# Altium schematic sheet USB.SchDoc(USB)
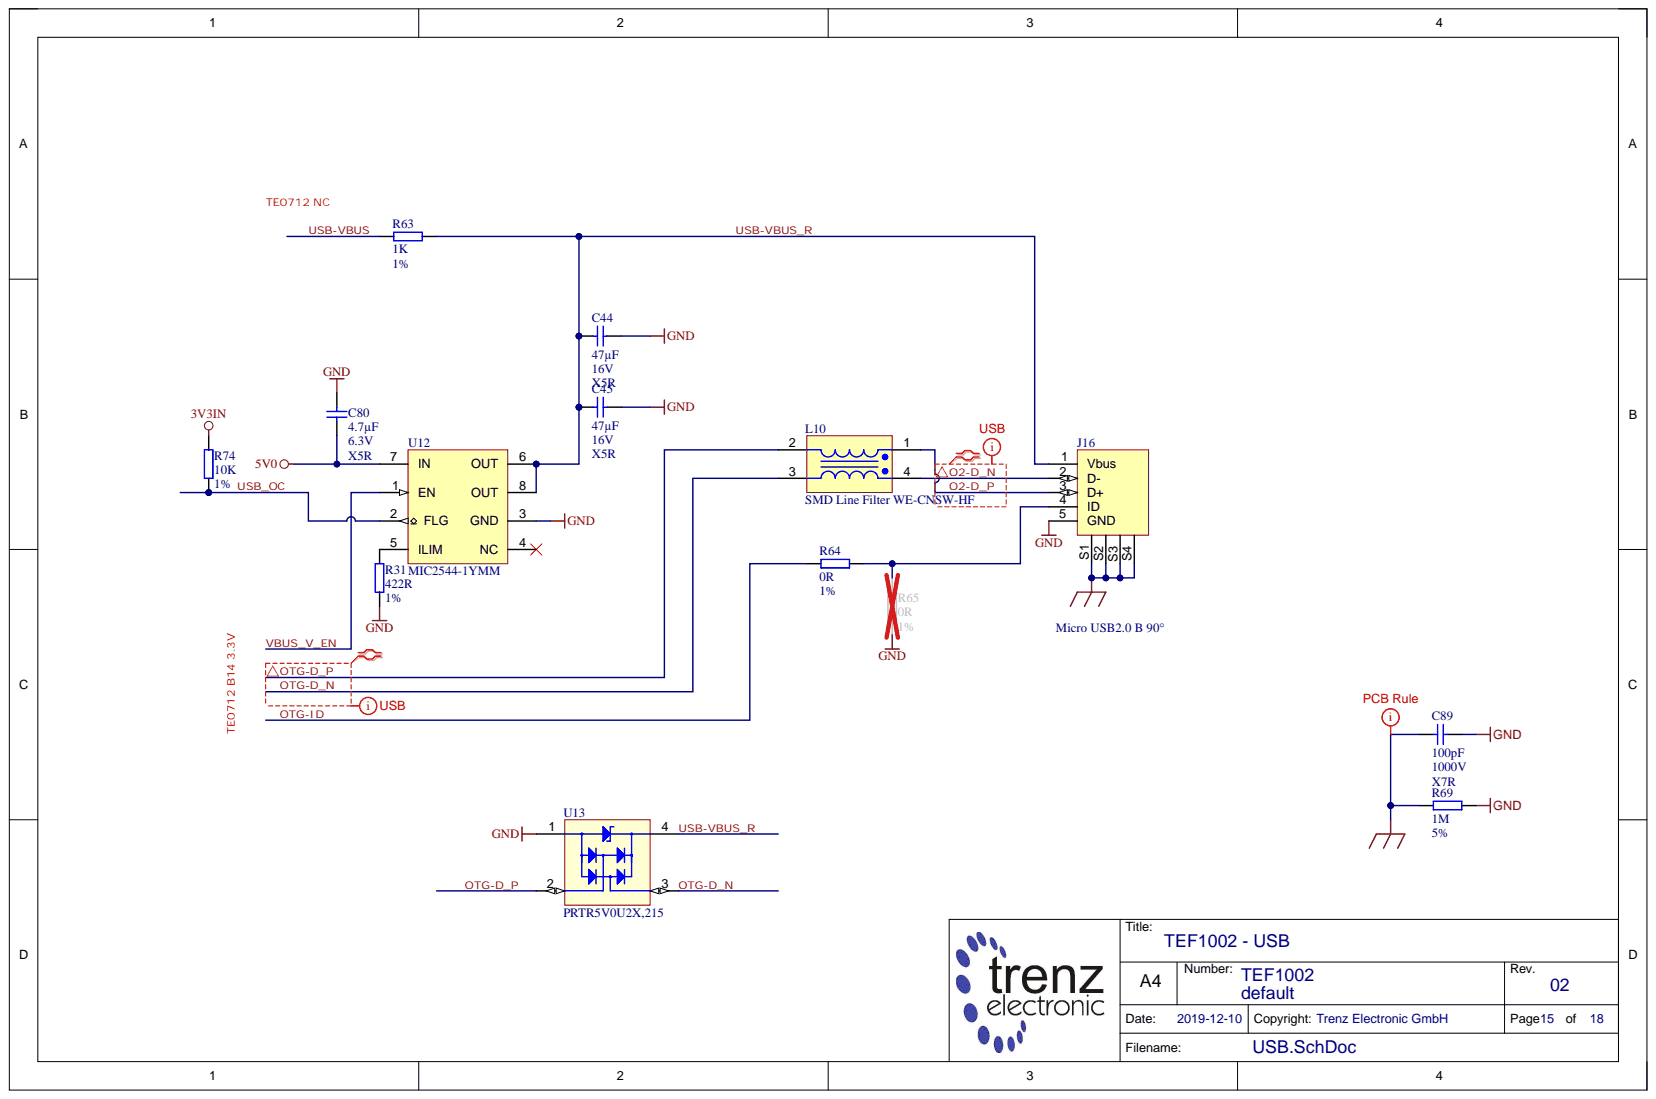
[diagram p0: USB.SchDoc]

## Parts
C44  47µF  [CAPC3216X180N]
C45  47µF  Technology: X5R  Voltage: 16V  [CAPC3216X180N]
C80  4.7µF  Voltage: 6.3V  [CAPC1005X60N]
C89  100pF  [CAPC3216X180N]
J16  Micro USB2.0 B 90°  [629105150521]
L10  SMD Line Filter WE-CNSW-HF  [WE-CNSW-HF]
R31  422R  Tolerance: 1%  [RESC1005X40N]
R63  1K  Tolerance: 1%  [RESC1005X40N]
R64  0R  Tolerance: 1%  [RESC1005X40N]
R65  0R  Tolerance: 1%  [RESC1005X40N]
R69  1M  Tolerance: 5%  [RESC3216X65N]
R74  10K  Tolerance: 1%  [RESC1005X40N]
U12  MIC2544-1YMM  [SOP65P490X110-8N]
U13  PRTR5V0U2X,215  [SOT190P229X114-4N]

## Nets
3V3IN: R74.2
5V0: C80.1 U12.7(IN)
FGND_USB: C89.1 J16.S1 J16.S2 J16.S3 J16.S4 R69.1
GND: C44.2 C45.2 C80.2 C89.2 J16.5(GND) R31.2 R65.1 R69.2 U12.3(GND) U13.1
O2-D_N: J16.2(D-) L10.4
O2-D_P: J16.3(D+) L10.1
OTG-D_N: L10.3 U13.3
OTG-D_P: L10.2 U13.2
OTG-ID: R64.1
USB-VBUS: R63.1
USB-VBUS_R: C44.1 C45.1 J16.1(Vbus) R63.2 U12.6(OUT) U12.8(OUT) U13.4
USB_OC: R74.1 U12.2(FLG)
VBUS_V_EN: U12.1(EN)
NetJ16_4: J16.4(ID) R64.2 R65.2
NetR31_1: R31.1 U12.5(ILIM)

Not connected: U12 4(NC)

## Library data of the parts
C44 C45 = 24955: KON 47µF 16V  X5R 20% SMD BF 3216 (1206); Database Table Name: C_3216_1206; Mixed: SMT; Preferred: Yes; Temperature-Range: -55/+85; Value: 47u; AN: 24955; Case: 3216 (1206); Component Kind: Standard; Library Name: TE.DbLib; Tolerance: 20%
  C44: Technology: X5R; Voltage: 16V
C80 = 24719: KON 4.7µF 6.3V X5R 20% SMD BF 1005 (0402); Database Table Name: C_1005_0402; Mixed: SMT; Preferred: Yes; Temperature-Range: -55/+85; Value: 4.7u; AN: 24719; Case: 1005 (0402); Component Kind: Standard; Library Name: TE.DbLib; Technology: X5R; Tolerance: 20%
C89 = 22679: KON 100pF 1000V X7R 10%  BF 3216 (1206); Database Table Name: C_3216_1206; Mixed: SMT; Preferred: Yes; Temperature-Range: -55/+125; Value: 100p; AN: 22679; Case: 3216 (1206); Component Kind: Standard; Library Name: TE.DbLib; Technology: X7R; Tolerance: 10%; Voltage: 1000V
J16 = 27235: Micro USB2.0 B Receptacle 90° SMD/THT; Database Table Name: CON_IO; Mixed: SMT; Temperature-Range: -40/+85; AN: 27235; Component Kind: Standard; H-ART: 629105150521; Library Name: TE.DbLib; HelpURL: B:\AD\adlib\datasheet\629105150521
L10 = 24514: Stromkompensierter SMD Line Filter HF 320mA 0,24Ohm 50V; Database Table Name: L_SMD; H-ART: 744233670; Mixed: SMT; Preferred: Yes; AN: 24514; Component Kind: Standard; Library Name: TE.DbLib; Technology: 0.24Ohm; Trenz-Stock: Yes; Voltage: 320mA; HelpURL: B:\AD\adlib\datasheet\WE-CNSW HF
R31 = 23723: WID SMD 422R 1% 0,063W  BF 1005 (0402); Database Table Name: R_1005_0402; Mixed: SMT; Preferred: Yes; Value: 422; AN: 23723; Case: 1005 (0402); Component Kind: Standard; Library Name: TE.DbLib; Technology: TK100
R63 = 22752: WID SMD 1K 1% 0,063W  BF 1005 (0402); Database Table Name: R_1005_0402; H-ART: RC0402FR-071KL; Mixed: SMT; Preferred: Yes; AN: 22752; Case: 1005 (0402); Component Kind: Standard; Library Name: TE.DbLib; Technology: TK100; Value: 1K
R64 R65 = 22738: WID SMD 0R  BF 1005 (0402); Database Table Name: R_1005_0402; H-ART: RC0402JR-070RL; Mixed: SMT; Preferred: Yes; Value: 0; AN: 22738; Case: 1005 (0402); Component Kind: Standard; Library Name: TE.DbLib; Technology: TK100
R69 = 22757: WID SMD 1M 5% 0,25W  BF 3216 (1206); Database Table Name: R_3216_1206; Mixed: SMT; Preferred: Yes; AN: 22757; Case: 3216 (1206); Component Kind: Standard; Library Name: TE.DbLib; Technology: TK100; Value: 1M
R74 = 22750: WID SMD 10K 1% 0,063W BF 1005 (0402); Database Table Name: R_1005_0402; H-ART: RC0402FR-0710KL; Mixed: SMT; Preferred: Yes; AN: 22750; Case: 1005 (0402); Component Kind: Standard; Library Name: TE.DbLib; Technology: TK100; Value: 10K
U12 = 30005: Database Table Name: SWITCH; Mixed: SMT; Temperature-Range: -40/+85; AN: 30005; Case: MSOP8; Component Kind: Standard; Description: MIC2544-1YMM; H-ART: MIC2544-1YMM; Library Name: TE.DbLib; Storage-Temperature: -65/+150; HelpURL: b:\AD\adlib\datasheet\Microchip\MIC2544_48\
U13 = 24840: Database Table Name: DIODE; Mixed: SMT; Preferred: Yes; Temperature-Range: -40/+85; AN: 24840; Case: SOT143; Component Kind: Standard; Description: PRTR5V0U2X,215; H-ART: PRTR5V0U2X,215; Library Name: TE.DbLib; HelpURL: B:\AD\adlib\datasheet\CD143A-SR05
